# Altium schematic sheet Power Supply.SchDoc("Power Supply")
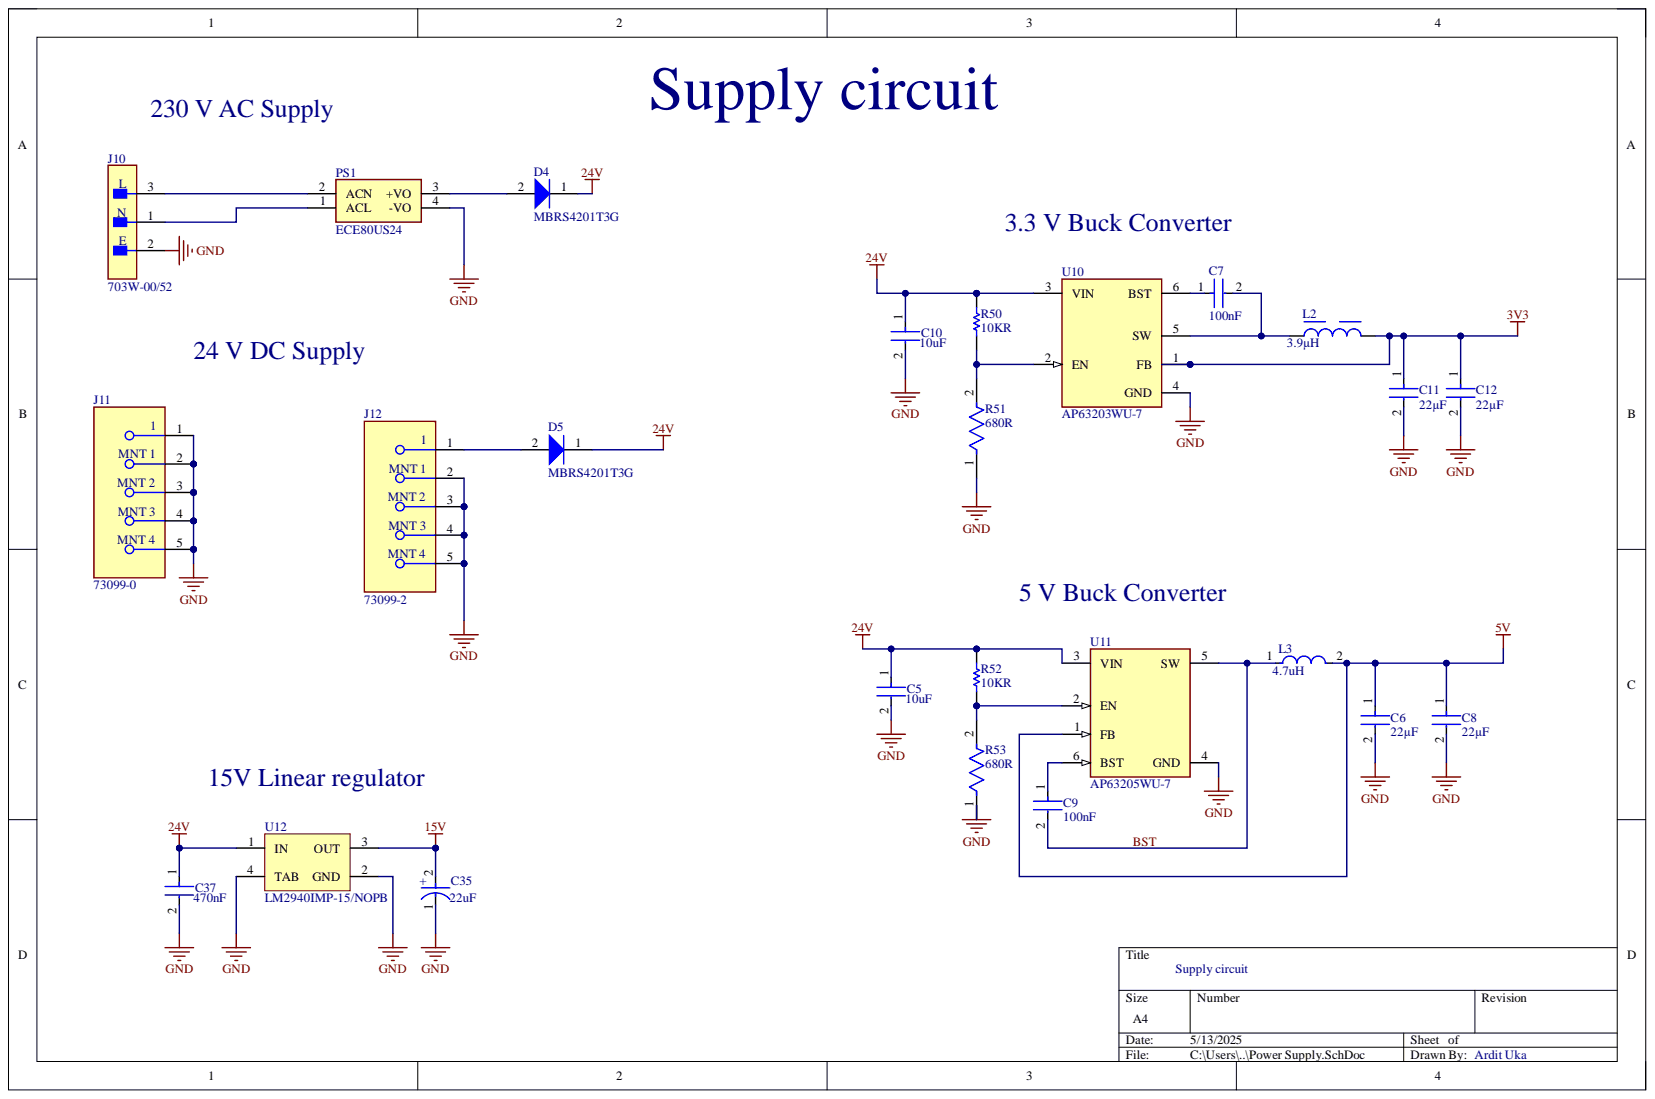
[diagram p0: Power Supply.SchDoc]

## Parts
C5  Capacitance: 10uF  [FP-CGA5L-0_3-0_1-MFG]  (hidden comment: CGA5L3X5R1H106M160AB)
C6  22µF  [FP-CL10-IPC_B]
C7  100nF  [FP-0603-L_1_6_0_15-W_0_81-IPC_C]
C8  22µF  [FP-CL10-IPC_B]
C9  100nF  [FP-0603-L_1_6_0_15-W_0_81-IPC_C]
C10  Capacitance: 10uF  [FP-CGA5L-0_3-0_1-MFG]  (hidden comment: CGA5L3X5R1H106M160AB)
C11  22µF  [FP-CL10-IPC_B]
C12  22µF  [FP-CL10-IPC_B]
C35  Capacitance: 22uF  [FP-T491D226K025AT-MFG]  (hidden comment: T491D226K025AT)
C37  Capacitance: 470nF  [FP-1206-L_3_2_0_2-W_1_6_0-MFG]  (hidden comment: 12065C474KAT2A)
D4  MBRS4201T3G  [ONSC-SMC-2-403-03_V]
D5  MBRS4201T3G  [ONSC-SMC-2-403-03_V]
J10  703W-00/52  [FP-703W-00-52-MFG]
J11  73099-0  [FP-73099-0-MFG]
J12  73099-2  [FP-73099-2-MFG]
L2  Inductance: 3.9µH  [WE-LQ_1812]  (hidden comment: 7440450039)
L3  Inductance: 4.7uH  [FP-CB2518-MFG]  (hidden comment: CB2518T4R7M)
PS1  ECE80US24  [ECE80US24]
R50  10KR  [RESC1609X55X30NL10T20]
R51  680R  [FP-ERJ3EK-IPC_A]
R52  10KR  [RESC1609X55X30NL10T20]
R53  680R  [FP-ERJ3EK-IPC_A]
U10  AP63203WU-7  [FP-TSOT26-MFG]
U11  AP63205WU-7  [FP-TSOT26-MFG]
U12  LM2940IMP-15/NOPB  [DCY0004A_M]

## Nets
3V3: C11.1 C12.1 L2.2 U10.1(FB)
5V: C6.1 C8.1 L3.2 U11.1(FB)
15V: C35.2 U12.3(OUT)
24V: C5.1 C10.1 C37.1 D4.1 D5.1 R50.2 R52.2 U10.3(VIN) U11.3(VIN) U12.1(IN)
GND: C5.2 C6.2 C8.2 C10.2 C11.2 C12.2 C35.1 C37.2 J10.2 J11.1 J11.2 J11.3 J11.4 J11.5 J12.2 J12.3 J12.4 J12.5 PS1.4(-VO) R51.1 R53.1 U10.4(GND) U11.4(GND) U12.2(GND) U12.4(TAB)
BST: C9.2 L3.1 U11.5(SW)
NetC7_1: C7.1 U10.6(BST)
NetC7_2: C7.2 L2.1 U10.5(SW)
NetC9_1: C9.1 U11.6(BST)
NetD4_2: D4.2 PS1.3(+VO)
NetD5_2: D5.2 J12.1
NetJ10_1: J10.1 PS1.1(ACL)
NetJ10_3: J10.3 PS1.2(ACN)
NetR50_1: R50.1 R51.2 U10.2(EN)
NetR52_1: R52.1 R53.2 U11.2(EN)

## Library data of the parts
C5 C10 = CMP-08246-001030-1: Cap Ceramic 10uF 50V X5R 20% Pad SMD 1206 +85°C Automotive T/R; Case Code (Imperial): 1206; Case Code (Metric): 3216; Case/Package: 1206; Component Kind: Standard; Dielectric: X5R; Height: 1.6mm; Lead Free: Lead Free; Length: 0.126inch; Library Name: Altium Content Vault; Max Operating Temperature: 85°C; Min Operating Temperature: -55°C; Mount: Surface Mount; Package Quantity: 2000; Packaging: Tape and Reel; Ratings: AEC-Q200; Termination: SMD/SMT; Thickness: 0.071inch; Tolerance: 20%; Voltage Rating: 50V; Voltage Rating (DC): 50V; Weight: 0.000571oz; Width: 0.063inch
C6 C8 C11 C12 = CMP-13271-002755-1: Cap Ceramic 22uF 16V X5R ±20% Pad SMD 0603 +85°C T/R; Capacitance: 22uF; Case Code (Imperial): 0603; Case Code (Metric): 1608; Case/Package: 0603; Component Kind: Standard; Library Name: Altium Content Vault; Max Operating Temperature: 85°C; Min Operating Temperature: -55°C; Mount: Surface Mount; Packaging: Tape and Reel; Tolerance: 20%; Voltage Rating: 16V
C7 C9 = CMP-2006-04386-2: Automotive Ceramic Capacitor, 0603, 100nF, 10%, X7R, 15%, 50V; Dissipation Factor: 2.5%; Insulation Resistance: 100GR; Capacitance: 100nF; Case Code (Imperial): 0603; Case Code (Metric): 1608; Case/Package: 0603; Component Kind: Standard; Construction: Flat; Contact Plating: Tin; Depth: 0.81mm; Dielectric: X7R; Dielectric Material: Ceramic; Height: 0.9mm; Lead Free: Lead Free; Length: 1.6mm; Library Name: Altium Content Vault; Max Operating Temperature: 125°C; Min Operating Temperature: -55°C; Mount: Surface Mount; Number of Pins: 2; Package Quantity: 1; Packaging: Tape and Reel; Radiation Hardening: No; Ratings: AEC-Q200; REACH SVHC: No SVHC; RoHS Compliant: Yes; Series: -; Termination: SMD/SMT; Thickness: 0.035inch; Tolerance: 10%; Voltage: 50V; Voltage Rating: 50V; Voltage Rating (DC): 50V; Width: 0.032inch
C35 = CMP-03017-000021-1: CAP TANT 22UF 10% 25V 2917; Dielectric Material: Tantalum; Dissipation Factor: 6%; ESR (Equivalent Series Resistance): 800mR; Leakage Current: 5.5uA; Life (Hours): 2000Hour; Polarity: Polar; Ripple Current: 433mA; Case Code (Imperial): 2917; Case Code (Metric): 7343; Case/Package: 2917; Component Kind: Standard; Depth: 4.3mm; Features: General Purpose; Height: 2.8mm; Height - Seated (Max): 0.122inch; Lead Free: Lead Free; Length: 7.3mm; Library Name: Altium Content Vault; Max Operating Temperature: 125°C; Min Operating Temperature: -55°C; Mount: Surface Mount; Number of Pins: 2; Package Quantity: 1; Packaging: Tape and Reel; Radiation Hardening: No; REACH SVHC: No SVHC; Termination: SMD/SMT; Tolerance: 10%; Voltage: 25V; Voltage Rating: 25V; Voltage Rating (DC): 25V; Width: 4.3mm
C37 = CMP-04427-019683-1: General Purpose Ceramic Capacitor, 1206, 470nF, 10%, X7R, 15%, 50V; Dissipation Factor: 2.5%; Case Code (Imperial): 1206; Case Code (Metric): 3216; Case/Package: 1206; Component Kind: Standard; Construction: Flat; Contact Plating: Tin; Depth: 1.6mm; Dielectric: X7R; Dielectric Material: Ceramic; Height: 1.52mm; Lead Free: Lead Free; Length: 3.2mm; Library Name: Altium Content Vault; Max Operating Temperature: 125°C; Min Operating Temperature: -55°C; Mount: Surface Mount; Number of Pins: 2; Package Quantity: 1; Packaging: Tape and Reel; Radiation Hardening: No; REACH SVHC: No SVHC; RoHS Compliant: Yes; Series: 1206; Size: 1.52mm; Termination: SMD/SMT; Thickness: 0.06inch; Tolerance: 10%; Voltage: 50V; Voltage Rating: 50V; Voltage Rating (DC): 50V; Width: 1.6mm
D4 D5 = CMP-1051-00011-1: 200 V, 4 A Schottky Fast Soft-Recovery Power Rectifier, 2-Pin SMC, Pb-Free, Tape and Reel; Manufacturer: On Semiconductor; Configuration: Single; IFSM Max (A): 100; trr Max (ns): 35; Component Kind: Standard; ComponentLink1Description: Manufacturer URL; ComponentLink1URL: http://www.onsemi.com/; ComponentLink2Description: Datasheet; ComponentLink2URL: http://www.onsemi.cn/pub_link/Collateral/MBRS4201T3-D.PDF; ComponentLink3Description: Package Specification; ComponentLink3URL: http://www.onsemi.com/pub_link/Collateral/403-03.PDF; DatasheetVersion: Rev. 5; Library Name: Altium Content Vault; Mounting Technology: Surface Mount; PackageDescription: 2-Pin Small Molded Package, J-Bend Lead, Body 5.845 x 6.855 mm; PackageReference: SMC-2-403-03; PackageVersion: Rev. E, 08/2005; Packing: Tape and Reel; PartNumber: MBRS4201T3G; RoHS: TRUE
J10 = CMP-64678-000001-1: PWR ENT RCPT IEC320-C14 PNL SLDR; Approvals: UL; Connector Type: Receptacle; Current: 15A; Fuse: No; Max Voltage Rating (AC): 250V; Number of Contacts: 3; Number of Poles: 2; Panel Thickness: 0.12inch; Pitch: 7mm; Color: Black; Component Kind: Standard; Contact Plating: Tin; Current Rating: 15A; Gender: Receptacle; Housing Material: Thermoplastic; Lead Free: Lead Free; Library Name: Altium Content Vault; Max Current Rating: 15A; Mount: Through Hole; Number of Positions: 3; Orientation: Right Angle; Package Quantity: 100; Packaging: Bulk; REACH SVHC: No SVHC; Termination: Solder; Voltage Rating: 250V; Voltage Rating (AC): 250V; Voltage Rating (DC): 250V
J11 = CMP-73906-000007-1: R/A 4MM MOUNTG SAFE JACK/PCB BLK; Component Kind: Standard; Library Name: Altium Content Vault
J12 = CMP-73906-000005-1: R/A 4MM MOUNTG SAFE JACK/PCB RED; Component Kind: Standard; Library Name: Altium Content Vault
L2 = CMP-0227-00629-2: NexarPartId: 14415825; Core Material: Ferrite; Current Rating: 1.32A; DC Resistance (DCR): 140mΩ; Inductance Tolerance: 20%; Q Factor: 40; Saturation Current: 2.5A; Self Resonant Frequency: 54MHz; Shielding: Unshielded; Test Frequency: 1MHz; Ambient Temperature Range High: 85°C; Ambient Temperature Range Low: -40°C; Case Code (Imperial): 1812; Case Code (Metric): 4532; Case/Package: 1812; Component Kind: Standard; Composition: Wirewound; Height: 2.6mm; Height - Seated (Max): 2.8956mm; Length: 4.5mm; Library Name: Altium Content Vault; Max DC Current: 1.32A; Max Operating Temperature: 125°C; Min Operating Temperature: -40°C; Mount: Surface Mount; Packaging: Tape & Reel (TR); REACH SVHC: Unknown; RMS Current (Irms): 1.32A; RoHS: Compliant; Termination: SMD/SMT; Tolerance: 20%; Width: 3.2mm
L3 = CMP-14484-000021-1: SMD Inductors 4.7uH ±20% 1.1A 0.169Ω 1007; Core Material: Ferrite; DC Resistance (DCR): 130mR; Resistance: 0.13R; Saturation Current: 340mA; Self Resonant Frequency: 46MHz; Shielding: Unshielded; Test Frequency: 7.96MHz; Case Code (Imperial): 1007; Case Code (Metric): 2518; Case/Package: 1007; Component Kind: Standard; Current Rating: 1.1A; Halogen Free: Halogen Free; Height: 0.072inch; Inductance Tolerance: 20%; Lead Free: Lead Free; Length: 0.098inch; Library Name: Altium Content Vault; Max DC Current: 1.1A; Max Operating Temperature: 105°C; Min Operating Temperature: -40°C; Mount: Surface Mount; Number of Pins: 2; Package Quantity: 2000; Packaging: Tape and Reel; Resistor Type: Wirewound; RoHS Compliant: Yes; Series: CB; Termination: SMD/SMT; Tolerance: 20%; Width: 0.072inch
PS1 = ECE80US24: Power Supply; Manufacturer_Name: XP POWER; Component Kind: Standard; Datasheet Link: https://www.mouser.com/datasheet/2/942/SF_ECE80-1508618.pdf; Height: 28.5mm; Manufacturer_Part_Number: ECE80US24; Mouser Part Number: 209-ECE80US24; Mouser Price/Stock: https://www.mouser.co.uk/ProductDetail/XP-Power/ECE80US24?qs=w%2Fv1CP2dgqqAxOIHJTnUbA%3D%3D
R50 R52 = CMP-2000-03691-1: Manufacturer: Vishay Dale; Part Number: TNPW060310K0BEEA; Component Kind: Standard; Library Name: Altium Content Vault
R51 R53 = CMP-2000-00612-2: RES SMD 680 OHM 1% 1/10W 0603; Max Power Dissipation: 100mW; Temperature Coefficient: 100ppm/°C; Voltage Rating: 75V; Case Code (Imperial): 0603; Case Code (Metric): 1608; Case/Package: 0603; Component Kind: Standard; Construction: Rectangular; Contact Plating: Tin; Depth: 0.8mm; Features: Automotive AEC-Q200; Height: 0.022inch; Lead Free: Lead Free; Length: 1.6mm; Library Name: Altium Content Vault; Max Operating Temperature: 155°C; Military Standard: Not; Min Operating Temperature: -55°C; Mount: Surface Mount; Number of Pins: 2; Number of Terminations: 2; Package Quantity: 1; Packaging: Tape and Reel; Power Rating: 100mW; Radiation Hardening: No; REACH SVHC: No SVHC; Resistance: 680R; Resistance Tolerance: 1%; Resistor Type: Thick Film; RoHS Compliant: Yes; Series: ERJ; Termination: SMD/SMT; Tolerance: 1%; Voltage Rating (DC): 75V; Width: 0.031inch
U10 = CMP-12757-000006-1: IC REG BUCK 3.3V 2A TSOT26; Manufacturer: Diodes Inc.; Max Junction Temp: +160°C; Max Supply Voltage: 32V; Min Supply Voltage: 3.8V; Nominal Supply Current: 22uA; Number Of Outputs: 1; Output Type: Fixed; Standoff Height: 0.01mm; Switching Frequency: 1.1MHz; Ambient Temperature Range High: +85°C; Ambient Temperature Range Low: -40°C; Automotive: No; Category: IC; Component Kind: Standard; ComponentLink2Description: Datasheet; ComponentLink2URL: https://www.diodes.com/assets/Datasheets/AP63200-AP63201-AP63203-AP63205.pdf; Device Class L1: Integrated Circuits (ICs); Device Class L2: Power Management ICs; Device Class L3: Voltage Regulators - Switching; Digikey Description: IC REG BUCK 3.3V 2A TSOT26; Footprint Url: https://www.diodes.com/assets/Package-Files/TSOT26.pdf; Height: 1mm; Lead Free: Yes; Library Name: Altium Content Vault; Manufacturer Part Number: AP63203WU-7; Mouser Description: AP63203 Series 3.3 V 2 A SMT Fixed Low IQ Synchronous Buck - TSOT-26; Octopart: https://octopart.com/ap63203wu-7-diodes+inc.-98099550; Output Current: 2A; Output Voltage: 3.3V; Package: TSOT26; RoHS: TRUE; Switching Topology: Buck; Temperature: to +160°C; Temperature Range High: +160°C
U11 = CMP-12757-000001-1: IC REG BUCK 5V 2A TSOT26; Manufacturer: Diodes Inc.; Max Duty Cycle: 100%; Max Junction Temp: +160°C; Max Supply Voltage: 32V; Min Supply Voltage: 3.8V; Nominal Supply Current: 22uA; Number Of Outputs: 1; Output Type: Fixed; Standoff Height: 0.01mm; Switching Frequency: 1100kHz; Ambient Temperature Range High: +85°C; Ambient Temperature Range Low: -40°C; Automotive: No; Category: IC; Component Kind: Standard; ComponentLink2Description: Datasheet; ComponentLink2URL: https://www.diodes.com/assets/Datasheets/AP63200-AP63201-AP63203-AP63205.pdf; Device Class L1: Integrated Circuits (ICs); Device Class L2: Power Management ICs; Device Class L3: Voltage Regulators - Switching; Digikey Description: IC REG BUCK 5V 2A TSOT26; Footprint Url: https://www.diodes.com/assets/Package-Files/TSOT26.pdf; Height: 1mm; Lead Free: Yes; Library Name: Altium Content Vault; Manufacturer Part Number: AP63205WU-7; Octopart: https://octopart.com/ap63205wu-7-diodes+inc.-98099551; Output Current: 2A; Output Voltage: 5V; Package: TSOT26; RoHS: TRUE; Switching Topology: Buck; Temperature: -40°C to +85°C; Temperature Range High: +85°C; Temperature Range Low: -40°C
U12 = CMP-0062-01733-3: 1A Low Dropout Regulator, 4-pin SOT-223, Pb-Free; Manufacturer: Texas Instruments; CompType: LDO IC; PackageTopMarking: XYTT*L70B; PowerWise: N; Code_IPC: SOT223-4; Code_JEDEC: TO-261-AA; Component Kind: Standard; ComponentLink1Description: Datasheet URL; ComponentLink1URL: http://www.ti.com/general/docs/lit/getliterature.tsp?genericPartNumber=LM2940-N&fileType=pdf; Library Name: Altium Content Vault; PackageDescription: Molded SOT-223, 6.50 x 3.56 x 1.6mm Body, 4 Lead, 2.3mm Pitch; PackageReference: DCY0004A; PinCount: 4; RoHS: Y
